# Altium schematic sheet 10_ATK-DLMP257_NET3.SchDoc
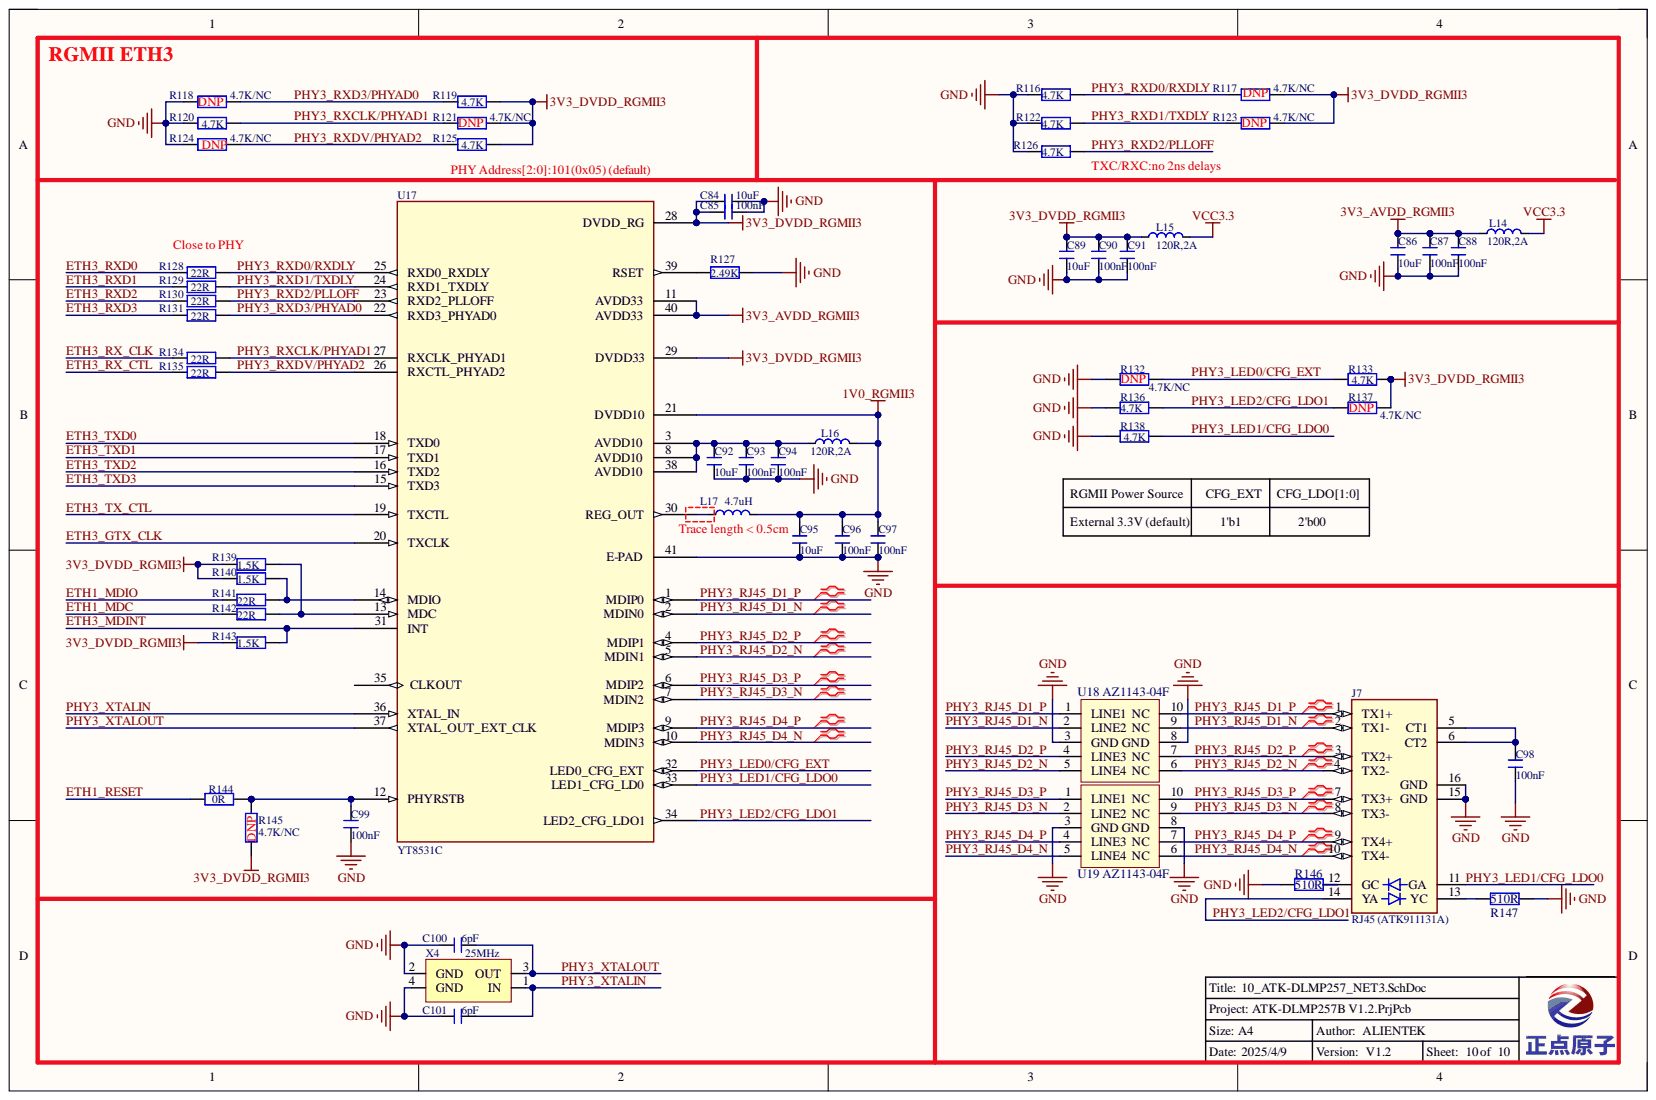
[diagram p0: 10_ATK-DLMP257_NET3.SchDoc]

## Parts
C84  10uF  [C 0603]
C85  100nF  [C 0402]
C86  10uF  [C 0603]
C87  100nF  [C 0402]
C88  100nF  [C 0402]
C89  10uF  [C 0603]
C90  100nF  [C 0402]
C91  100nF  [C 0402]
C92  10uF  [C 0603]
C93  100nF  [C 0402]
C94  100nF  [C 0402]
C95  10uF  [C 0603]
C96  100nF  [C 0402]
C97  100nF  [C 0402]
C98  100nF  [C 0603]
C99  100nF  [C 0402]
C100  6pF  [C 0402]
C101  6pF  [C 0402]
J7  RJ45 (ATK911131A)  [HR911131A]
L14  120R,2A  [L 0603]
L15  120R,2A  [L 0603]
L16  120R,2A  [L 0603]
L17  4.7uH  [L_2520]
R116  4.7K  [R 0402]
R117  4.7K/NC  [R 0402]
R118  4.7K/NC  [R 0402]
R119  4.7K  [R 0402]
R120  4.7K  [R 0402]
R121  4.7K/NC  [R 0402]
R122  4.7K  [R 0402]
R123  4.7K/NC  [R 0402]
R124  4.7K/NC  [R 0402]
R125  4.7K  [R 0402]
R126  4.7K  [R 0402]
R127  2.49K  [R 0402]
R128  22R  [R 0402]
R129  22R  [R 0402]
R130  22R  [R 0402]
R131  22R  [R 0402]
R132  4.7K/NC  [R 0402]
R133  4.7K  [R 0402]
R134  22R  [R 0402]
R135  22R  [R 0402]
R136  4.7K  [R 0603]
R137  4.7K/NC  [R 0603]
R138  4.7K  [R 0603]
R139  1.5K  [R 0402]
R140  1.5K  [R 0402]
R141  22R  [R 0402]
R142  22R  [R 0402]
R143  1.5K  [R 0402]
R144  0R  [R 0402]
R145  4.7K/NC  [R 0402]
R146  510R  [R 0603]
R147  510R  [R 0603]
U17  YT8531C  [QFN40]
U18  AZ1143-04F  [DFN2510P10E]
U19  AZ1143-04F  [DFN2510P10E]
X4  25MHz  [XTAL-3225]

## Nets
1V0_RGMII3: C95.2 C96.2 C97.2 L16.2 L17.2 U17.21(DVDD10)
3V3_AVDD_RGMII3: C86.2 C87.2 C88.2 L14.1 U17.11(AVDD33) U17.40(AVDD33)
3V3_DVDD_RGMII3: C84.2 C85.2 C89.2 C90.2 C91.2 L15.1 R117.2 R119.2 R121.2 R123.2 R125.2 R133.2 R137.2 R139.1 R140.1 R143.1 R145.2 U17.28(DVDD_RG) U17.29(DVDD33)
GND: C84.1 C85.1 C86.1 C87.1 C88.1 C89.1 C90.1 C91.1 C92.1 C93.1 C94.1 C95.1 C96.1 C97.1 C98.1 C99.1 C100.2 C101.2 J7.15(GND) J7.16(GND) R116.1 R118.2 R120.2 R122.1 R124.2 R126.1 R127.2 R132.1 R136.1 R138.1 R146.1 R147.2 U17.41(E-PAD) U18.3(GND) U18.8(GND) U19.3(GND) U19.8(GND) X4.2(GND) X4.4(GND)
VCC3.3: L14.2 L15.2
ETH1_MDC: R142.1
ETH1_MDIO: R141.1
ETH1_RESET: R144.1
ETH3_GTX_CLK: U17.20(TXCLK)
ETH3_MDINT: R143.2 U17.31(INT)
ETH3_RX_CLK: R134.2
ETH3_RX_CTL: R135.2
ETH3_RXD0: R128.2
ETH3_RXD1: R129.2
ETH3_RXD2: R130.2
ETH3_RXD3: R131.2
ETH3_TX_CTL: U17.19(TXCTL)
ETH3_TXD0: U17.18(TXD0)
ETH3_TXD1: U17.17(TXD1)
ETH3_TXD2: U17.16(TXD2)
ETH3_TXD3: U17.15(TXD3)
PHY3_LED0/CFG_EXT: R132.2 R133.1 U17.32(LED0_CFG_EXT)
PHY3_LED1/CFG_LDO0: J7.11(GA) R138.2 U17.33(LED1_CFG_LD0)
PHY3_LED2/CFG_LDO1: J7.14(YA) R136.2 R137.1 U17.34(LED2_CFG_LDO1)
PHY3_RJ45_D1_N: J7.2(TX1-) U17.2(MDIN0) U18.2(LINE2) U18.9(NC)
PHY3_RJ45_D1_P: J7.1(TX1+) U17.1(MDIP0) U18.1(LINE1) U18.10(NC)
PHY3_RJ45_D2_N: J7.4(TX2-) U17.5(MDIN1) U18.5(LINE4) U18.6(NC)
PHY3_RJ45_D2_P: J7.3(TX2+) U17.4(MDIP1) U18.4(LINE3) U18.7(NC)
PHY3_RJ45_D3_N: J7.8(TX3-) U17.7(MDIN2) U19.2(LINE2) U19.9(NC)
PHY3_RJ45_D3_P: J7.7(TX3+) U17.6(MDIP2) U19.1(LINE1) U19.10(NC)
PHY3_RJ45_D4_N: J7.10(TX4-) U17.10(MDIN3) U19.5(LINE4) U19.6(NC)
PHY3_RJ45_D4_P: J7.9(TX4+) U17.9(MDIP3) U19.4(LINE3) U19.7(NC)
PHY3_RXCLK/PHYAD1: R120.1 R121.1 R134.1 U17.27(RXCLK_PHYAD1)
PHY3_RXD0/RXDLY: R116.2 R117.1 R128.1 U17.25(RXD0_RXDLY)
PHY3_RXD1/TXDLY: R122.2 R123.1 R129.1 U17.24(RXD1_TXDLY)
PHY3_RXD2/PLLOFF: R126.2 R130.1 U17.23(RXD2_PLLOFF)
PHY3_RXD3/PHYAD0: R118.1 R119.1 R131.1 U17.22(RXD3_PHYAD0)
PHY3_RXDV/PHYAD2: R124.1 R125.1 R135.1 U17.26(RXCTL_PHYAD2)
PHY3_XTALIN: C101.1 U17.36(XTAL_IN) X4.1(IN)
PHY3_XTALOUT: C100.1 U17.37(XTAL_OUT_EXT_CLK) X4.3(OUT)
NetC92_2: C92.2 C93.2 C94.2 L16.1 U17.3(AVDD10) U17.8(AVDD10) U17.38(AVDD10)
NetC98_2: C98.2 J7.5(CT1) J7.6(CT2)
NetC99_2: C99.2 R144.2 R145.1 U17.12(PHYRSTB)
NetJ7_12: J7.12(GC) R146.2
NetJ7_13: J7.13(YC) R147.1
NetL17_1: L17.1 U17.30(REG_OUT)
NetR127_1: R127.1 U17.39(RSET)
NetR139_2: R139.2 R142.2 U17.13(MDC)
NetR140_2: R140.2 R141.2 U17.14(MDIO)

Not connected: U17 35(CLKOUT)

## Library data of the parts
C84-C101 = C: 无极性贴片电容; 版权归属: 源创客; BOM名称: 无极性贴片电容; Component Kind: Standard; 学习资源: www.ooocke.com; Library Name: Lycreturn.IntLib
J7 = HR911131A/C: 千兆以太网接口; Component Kind: Standard; Library Name: 接口类.SchLib
L14-L17 = L: 小功率贴片电感磁珠; 版权归属: 源创客; BOM名称: 小功率贴片电感; Component Kind: Standard; Library Name: 电阻-电容-电感.SchLib; 学习资源: www.ooocke.com
R116-R145 = R: 贴片电阻; 版权归属: 源创客; BOM名称: 贴片电阻; Component Kind: Standard; 学习资源: www.ooocke.com; Library Name: Lycreturn.IntLib
R146 R147 = R: 贴片电阻; 版权归属: 正点原子; BOM名称: 贴片电阻; Component Kind: Standard; 学习资源: www.openedv.com; Library Name: Lycreturn.IntLib
U17 = RTL8211F-CG: Component Kind: Standard; Library Name: ZZK_COMPONENT.IntLib
U18 U19 = AZ1143-04F: ESD保护二极管阵列; Component Kind: Standard; Library Name: 二极管-整流桥.SchLib
X4 = XTAL-4P: 4脚无源晶振; BOM名称: 4脚晶振; 版权归属: 源创客; Component Kind: Standard; 学习资源: www.ooocke.com; Library Name: Lycreturn.IntLib
